# Altium schematic sheet MCU.SchDoc
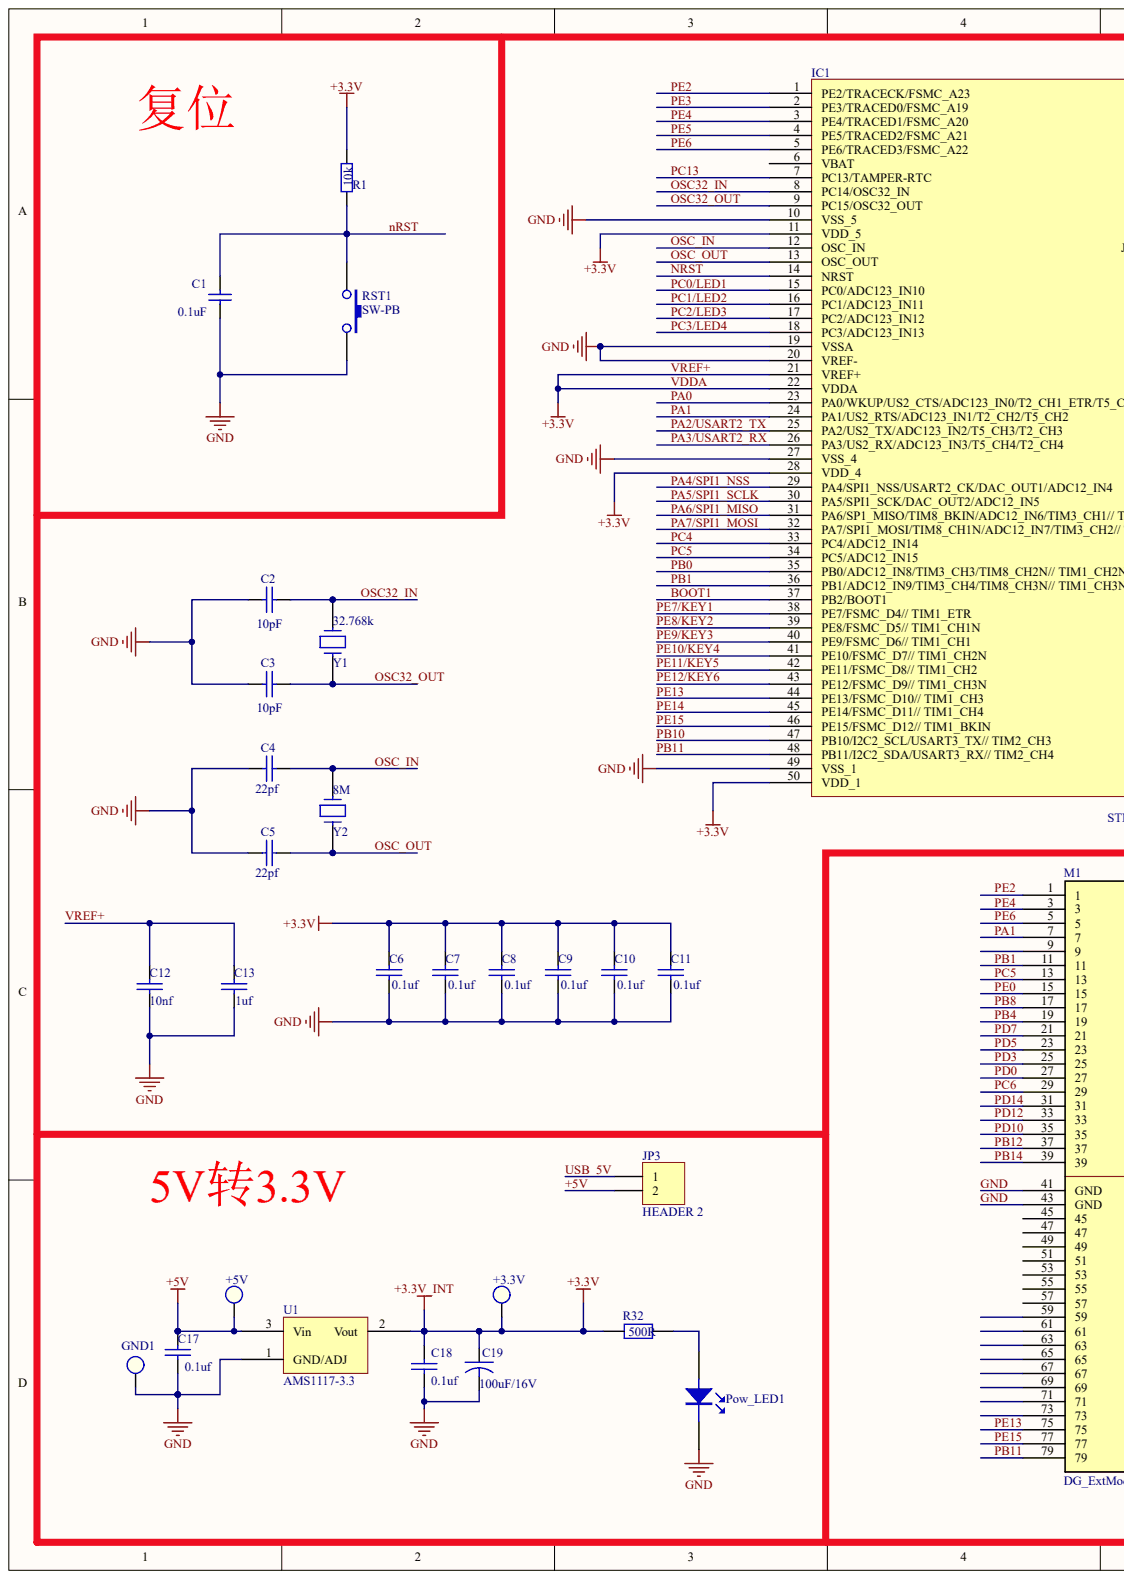
[diagram p0: MCU.SchDoc, left]
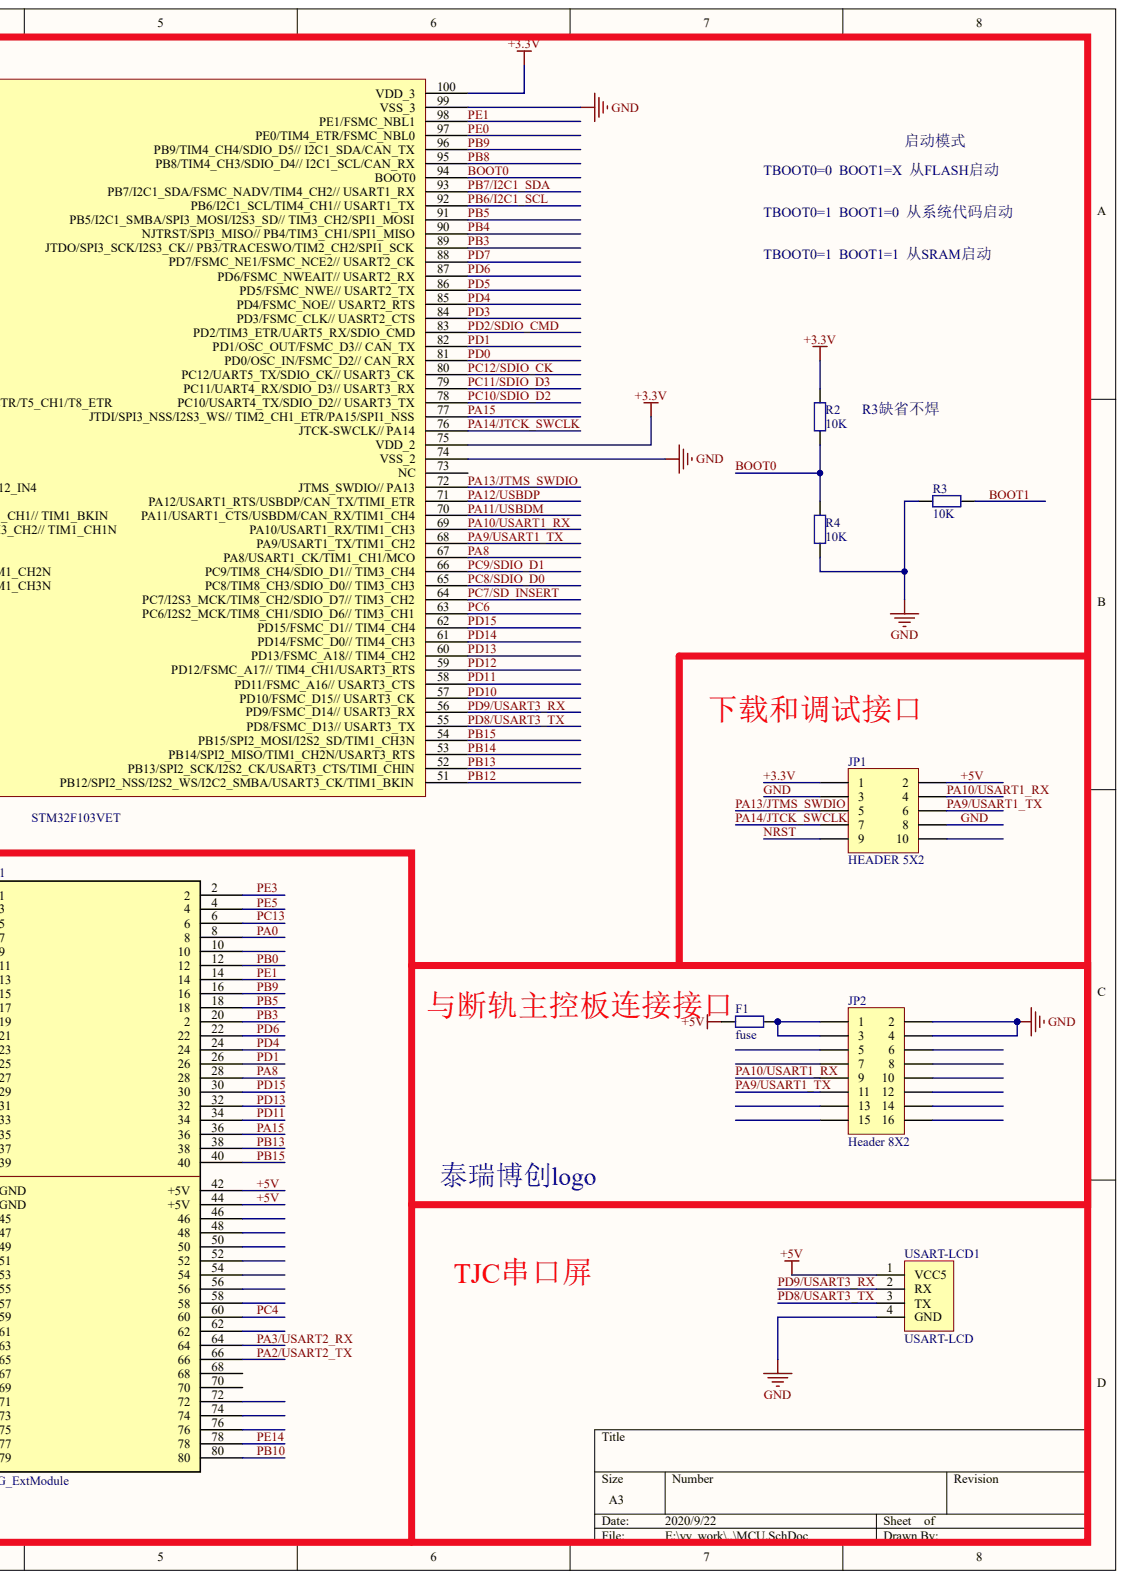
[diagram p1: MCU.SchDoc, right]

## Parts
+3.3V  3.3V
+5V  3.3V
C1  0.1uF
C2  10pF
C3  10pF
C4  22pf
C5  22pf
C6  0.1uf
C7  0.1uf
C8  0.1uf
C9  0.1uf
C10  0.1uf
C11  0.1uf
C12  10nf
C13  1uf
C17  0.1uf
C18  0.1uf
C19  100uF/16V
F1  fuse
GND1  3.3V  (value not drawn)
IC1  (no value)
JP1  HEADER 5X2
JP2  Header 8X2
JP3  HEADER 2
M1  DG_ExtModule
Pow_LED1  LED  (value not drawn)
R1  10k
R2  10K
R3  10K
R4  10K
R32  500R
RST1  SW-PB
Terrytec_LOGO  Terrytec LOGO  (value not drawn)
U1  AMS1117-3.3
USART-LCD1  USART-LCD
Y1  32.768k
Y2  8M

## Nets
+3.3V: +3.3V.1 C6.2 C7.2 C8.2 C9.2 C10.2 C11.2 C12.2 C13.2 C18.1 C19.1 IC1.11(VDD_5) IC1.21(VREF+) IC1.22(VDDA) IC1.28(VDD_4) IC1.50(VDD_1) IC1.75(VDD_2) IC1.100(VDD_3) JP1.1 R1.2 R2.2 R32.1 U1.2
+5V: +5V.1 C17.1 F1.1 JP1.2 JP3.2 M1.42(+5V) M1.44(+5V) U1.3 USART-LCD1.1(VCC5)
BOOT0: IC1.94(BOOT0) R2.1 R4.2
BOOT1: IC1.37(PB2/BOOT1) R3.2
GND: C1.1 C2.2 C3.2 C4.2 C5.2 C6.1 C7.1 C8.1 C9.1 C10.1 C11.1 C12.1 C13.1 C17.2 C18.2 C19.2 GND1.1 IC1.10(VSS_5) IC1.19(VSSA) IC1.20(VREF-) IC1.27(VSS_4) IC1.49(VSS_1) IC1.74(VSS_2) IC1.99(VSS_3) JP1.3 JP1.8 JP2.2 JP2.4 M1.41(GND) M1.43(GND) Pow_LED1.2 R3.1 R4.1 RST1.2 U1.1 USART-LCD1.4(GND)
NRST: C1.2 IC1.14(NRST) JP1.9 R1.1 RST1.1
OSC32_IN: C2.1 IC1.8(PC14/OSC32_IN) Y1.2
OSC32_OUT: C3.1 IC1.9(PC15/OSC32_OUT) Y1.1
OSC_IN: C4.1 IC1.12(OSC_IN) Y2.2
OSC_OUT: C5.1 IC1.13(OSC_OUT) Y2.1
PA0: IC1.23(PA0/WKUP/US2_CTS/ADC123_IN0/T2_CH1_ETR/T5_CH1/T8_ETR) M1.8
PA1: IC1.24(PA1/US2_RTS/ADC123_IN1/T2_CH2/T5_CH2) M1.7
PA2/USART2_TX: IC1.25(PA2/US2_TX/ADC123_IN2/T5_CH3/T2_CH3) M1.66
PA3/USART2_RX: IC1.26(PA3/US2_RX/ADC123_IN3/T5_CH4/T2_CH4) M1.64
PA4/SPI1_NSS: IC1.29(PA4/SPI1_NSS/USART2_CK/DAC_OUT1/ADC12_IN4)
PA5/SPI1_SCLK: IC1.30(PA5/SPI1_SCK/DAC_OUT2/ADC12_IN5)
PA6/SPI1_MISO: IC1.31(PA6/SP1_MISO/TIM8_BKIN/ADC12_IN6/TIM3_CH1//_TIM1_BKIN)
PA7/SPI1_MOSI: IC1.32
PA8: IC1.67(PA8/USART1_CK/TIM1_CH1/MCO) M1.28
PA9/USART1_TX: IC1.68(PA9/USART1_TX/TIM1_CH2) JP1.6 JP2.11
PA10/USART1_RX: IC1.69(PA10/USART1_RX/TIM1_CH3) JP1.4 JP2.9
PA11/USBDM: IC1.70(PA11/USART1_CTS/USBDM/CAN_RX/TIM1_CH4)
PA12/USBDP: IC1.71(PA12/USART1_RTS/USBDP/CAN_TX/TIMI_ETR)
PA13/JTMS_SWDIO: IC1.72(JTMS_SWDIO//_PA13) JP1.5
PA14/JTCK_SWCLK: IC1.76(JTCK-SWCLK//_PA14) JP1.7
PA15: IC1.77(JTDI/SPI3_NSS/I2S3_WS//_TIM2_CH1_ETR/PA15/SPI1_NSS) M1.36
PB0: IC1.35(PB0/ADC12_IN8/TIM3_CH3/TIM8_CH2N//_TIM1_CH2N) M1.12
PB1: IC1.36(PB1/ADC12_IN9/TIM3_CH4/TIM8_CH3N//_TIM1_CH3N) M1.11
PB3: IC1.89(JTDO/SPI3_SCK/I2S3_CK//_PB3/TRACESWO/TIM2_CH2/SPI1_SCK) M1.20
PB4: IC1.90(NJTRST/SPI3_MISO//_PB4/TIM3_CH1/SPI1_MISO) M1.19
PB5: IC1.91(PB5/I2C1_SMBA/SPI3_MOSI/I2S3_SD//_TIM3_CH2/SPI1_MOSI) M1.18
PB6/I2C1_SCL: IC1.92(PB6/I2C1_SCL/TIM4_CH1//_USART1_TX)
PB7/I2C1_SDA: IC1.93(PB7/I2C1_SDA/FSMC_NADV/TIM4_CH2//_USART1_RX)
PB8: IC1.95(PB8/TIM4_CH3/SDIO_D4//_I2C1_SCL/CAN_RX) M1.17
PB9: IC1.96(PB9/TIM4_CH4/SDIO_D5//_I2C1_SDA/CAN_TX) M1.16
PB10: IC1.47(PB10/I2C2_SCL/USART3_TX//_TIM2_CH3) M1.80
PB11: IC1.48(PB11/I2C2_SDA/USART3_RX//_TIM2_CH4) M1.79
PB12: IC1.51(PB12/SPI2_NSS/I2S2_WS/I2C2_SMBA/USART3_CK/TIM1_BKIN) M1.37
PB13: IC1.52(PB13/SPI2_SCK/I2S2_CK/USART3_CTS/TIMI_CHIN) M1.38
PB14: IC1.53(PB14/SPI2_MISO/TIM1_CH2N/USART3_RTS) M1.39
PB15: IC1.54(PB15/SPI2_MOSI/I2S2_SD/TIM1_CH3N) M1.40
PC0/LED1: IC1.15(PC0/ADC123_IN10)
PC1/LED2: IC1.16(PC1/ADC123_IN11)
PC2/LED3: IC1.17(PC2/ADC123_IN12)
PC3/LED4: IC1.18(PC3/ADC123_IN13)
PC4: IC1.33(PC4/ADC12_IN14) M1.60
PC5: IC1.34(PC5/ADC12_IN15) M1.13
PC6: IC1.63(PC6/I2S2_MCK/TIM8_CH1/SDIO_D6//_TIM3_CH1) M1.29
PC7/SD_INSERT: IC1.64(PC7/I2S3_MCK/TIM8_CH2/SDIO_D7//_TIM3_CH2)
PC8/SDIO_D0: IC1.65(PC8/TIM8_CH3/SDIO_D0//_TIM3_CH3)
PC9/SDIO_D1: IC1.66(PC9/TIM8_CH4/SDIO_D1//_TIM3_CH4)
PC10/SDIO_D2: IC1.78(PC10/USART4_TX/SDIO_D2//_USART3_TX)
PC11/SDIO_D3: IC1.79(PC11/UART4_RX/SDIO_D3//_USART3_RX)
PC12/SDIO_CK: IC1.80(PC12/UART5_TX/SDIO_CK//_USART3_CK)
PC13: IC1.7(PC13/TAMPER-RTC) M1.6
PD0: IC1.81(PD0/OSC_IN/FSMC_D2//_CAN_RX) M1.27
PD1: IC1.82(PD1/OSC_OUT/FSMC_D3//_CAN_TX) M1.26
PD2/SDIO_CMD: IC1.83(PD2/TIM3_ETR/UART5_RX/SDIO_CMD)
PD3: IC1.84(PD3/FSMC_CLK//_UASRT2_CTS) M1.25
PD4: IC1.85(PD4/FSMC_NOE//_USART2_RTS) M1.24
PD5: IC1.86(PD5/FSMC_NWE//_USART2_TX) M1.23
PD6: IC1.87(PD6/FSMC_NWEAIT//_USART2_RX) M1.22
PD7: IC1.88(PD7/FSMC_NE1/FSMC_NCE2//_USART2_CK) M1.21
PD8/USART3_TX: IC1.55(PD8/FSMC_D13//_USART3_TX) USART-LCD1.3(TX)
PD9/USART3_RX: IC1.56(PD9/FSMC_D14//_USART3_RX) USART-LCD1.2(RX)
PD10: IC1.57(PD10/FSMC_D15//_USART3_CK) M1.35
PD11: IC1.58(PD11/FSMC_A16//_USART3_CTS) M1.34
PD12: IC1.59(PD12/FSMC_A17//_TIM4_CH1/USART3_RTS) M1.33
PD13: IC1.60(PD13/FSMC_A18//_TIM4_CH2) M1.32
PD14: IC1.61(PD14/FSMC_D0//_TIM4_CH3) M1.31
PD15: IC1.62(PD15/FSMC_D1//_TIM4_CH4) M1.30
PE0: IC1.97(PE0/TIM4_ETR/FSMC_NBL0) M1.15
PE1: IC1.98(PE1/FSMC_NBL1) M1.14
PE2: IC1.1(PE2/TRACECK/FSMC_A23) M1.1
PE3: IC1.2(PE3/TRACED0/FSMC_A19) M1.2
PE4: IC1.3(PE4/TRACED1/FSMC_A20) M1.3
PE5: IC1.4(PE5/TRACED2/FSMC_A21) M1.4
PE6: IC1.5(PE6/TRACED3/FSMC_A22) M1.5
PE7/KEY1: IC1.38(PE7/FSMC_D4//_TIM1_ETR)
PE8/KEY2: IC1.39(PE8/FSMC_D5//_TIM1_CH1N)
PE9/KEY3: IC1.40(PE9/FSMC_D6//_TIM1_CH1)
PE10/KEY4: IC1.41(PE10/FSMC_D7//_TIM1_CH2N)
PE11/KEY5: IC1.42(PE11/FSMC_D8//_TIM1_CH2)
PE12/KEY6: IC1.43(PE12/FSMC_D9//_TIM1_CH3N)
PE13: IC1.44(PE13/FSMC_D10//_TIM1_CH3) M1.75
PE14: IC1.45(PE14/FSMC_D11//_TIM1_CH4) M1.78
PE15: IC1.46(PE15/FSMC_D12//_TIM1_BKIN) M1.77
USB_5V: JP3.1
NetF1_2: F1.2 JP2.1 JP2.3
NetPow_LED1_1: Pow_LED1.1 R32.2

Not connected: IC1 6(VBAT) 73(NC); JP1 10; JP2 5 6 7 8 10 12 13 14 15 16; M1 9 10 45 46 47 48 49 50 51 52 53 54 55 56 57 58 59 61 62 63 65 67 68 69 70 71 72 73 74 76

## Library data of the parts
+3.3V +5V C1-C13 C17-C19 F1 GND1 IC1 JP1-JP3 M1 Pow_LED1 R1-R4 R32 RST1 Terrytec_LOGO U1 USART-LCD1 Y1 Y2 = (no symbol name)
  C1: Published: 8-Jun-2000; LatestRevisionDate: 17-Jul-2002; LatestRevisionNote: Re-released for DXP Platform.; PackageReference: RAD-0.3; PackageDescription: Radial Cap, Thru-Hole; 2 Leads; 0.3 in Pin Spacing; Value: 0.1uF
  C2 C3: value: 10pF
  C4 C5: value: 22pf
  C6-C11 C17 C18: value: 0.1uf
  C12: value: 10nf
  C13: value: 1uf
  JP2: LatestRevisionDate: 17-Jul-2002; LatestRevisionNote: Re-released for DXP Platform.
  R1: value: 10k
  R2-R4: Published: 8-Jun-2000; LatestRevisionDate: 17-Jul-2002; LatestRevisionNote: Re-released for DXP Platform.; PackageReference: AXIAL-0.4; Value: 10K
  R32: value: 500R
  C1 JP2 R2-R4: Publisher: Altium Limited
